# Altium schematic sheet TMC2209.SchDoc
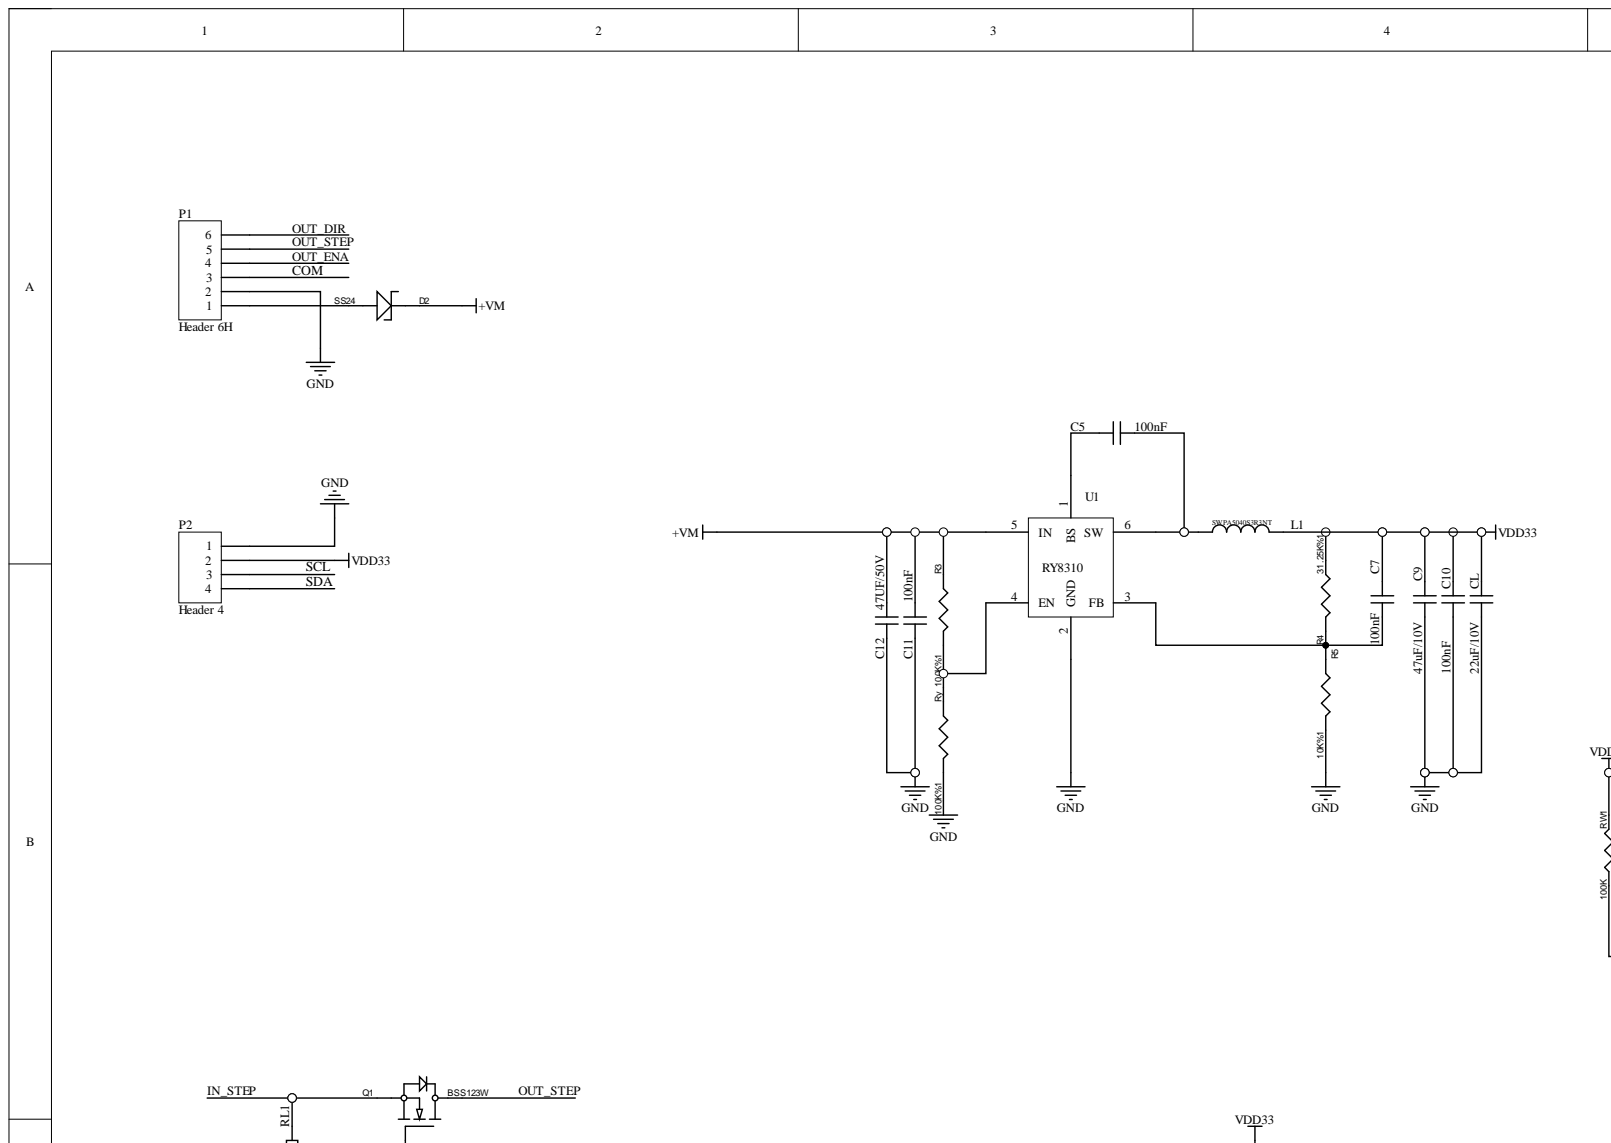
[diagram p0: TMC2209.SchDoc, top left]
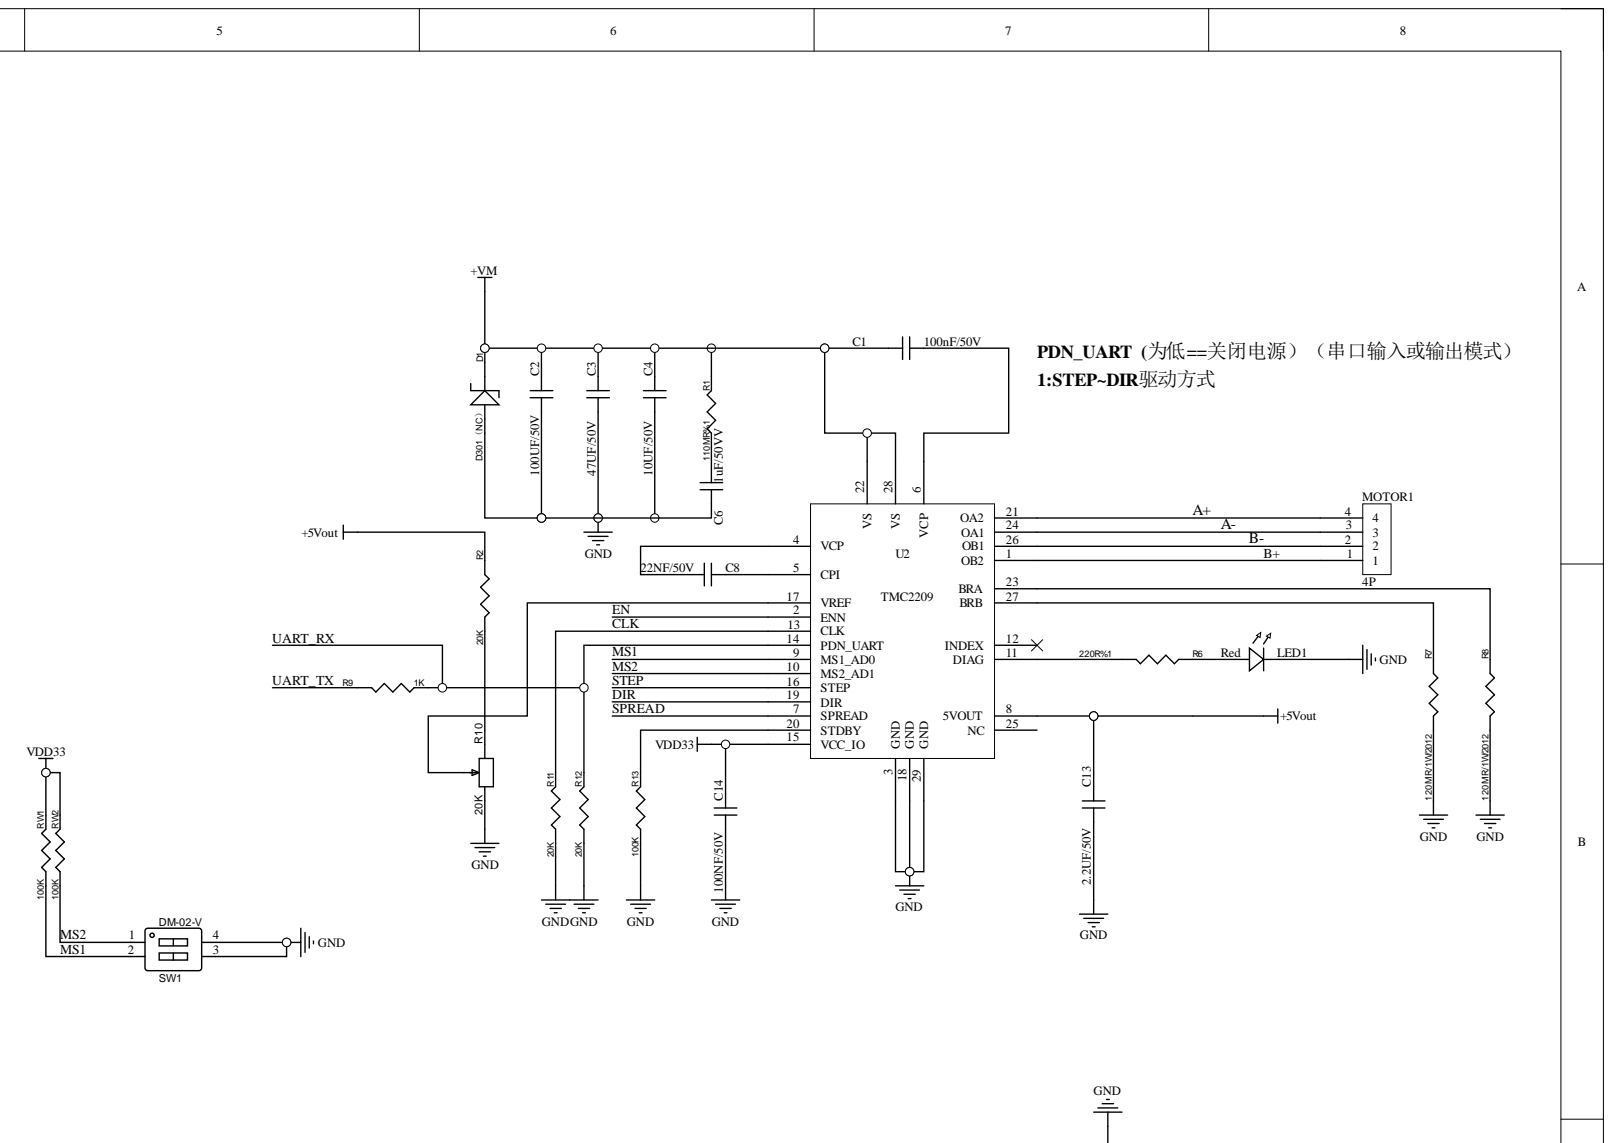
[diagram p1: TMC2209.SchDoc, top right]
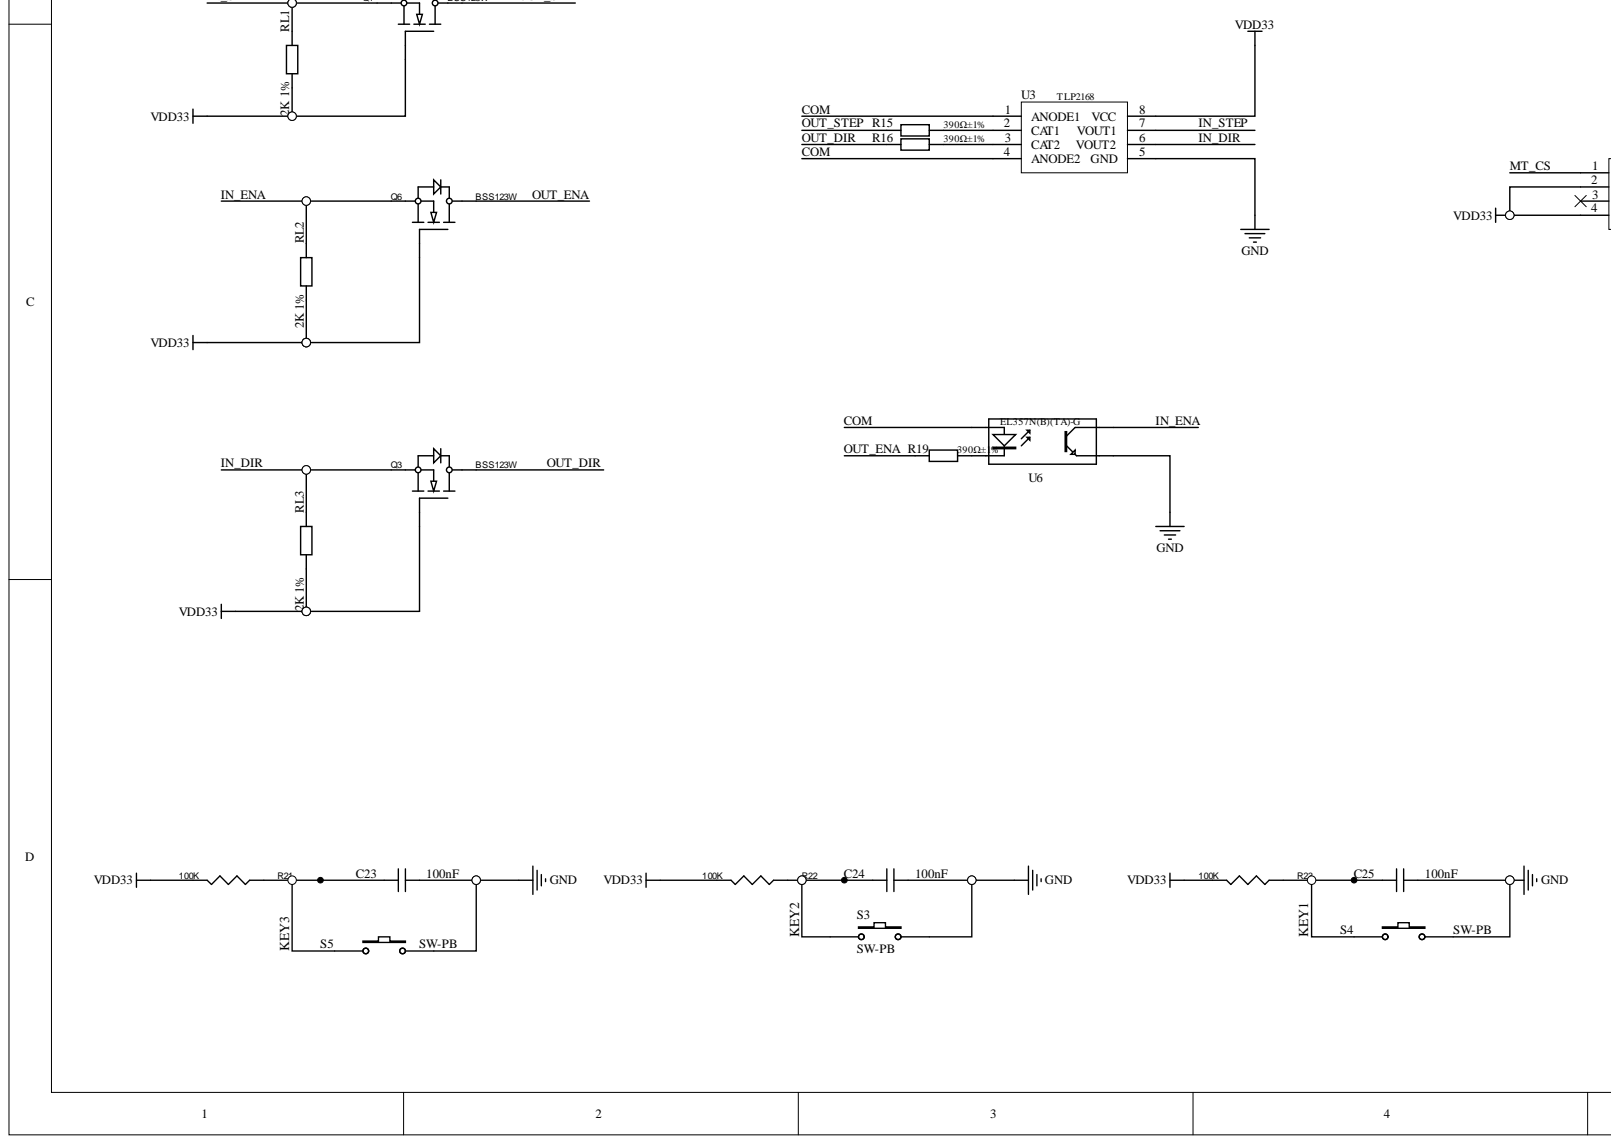
[diagram p2: TMC2209.SchDoc, bottom left]
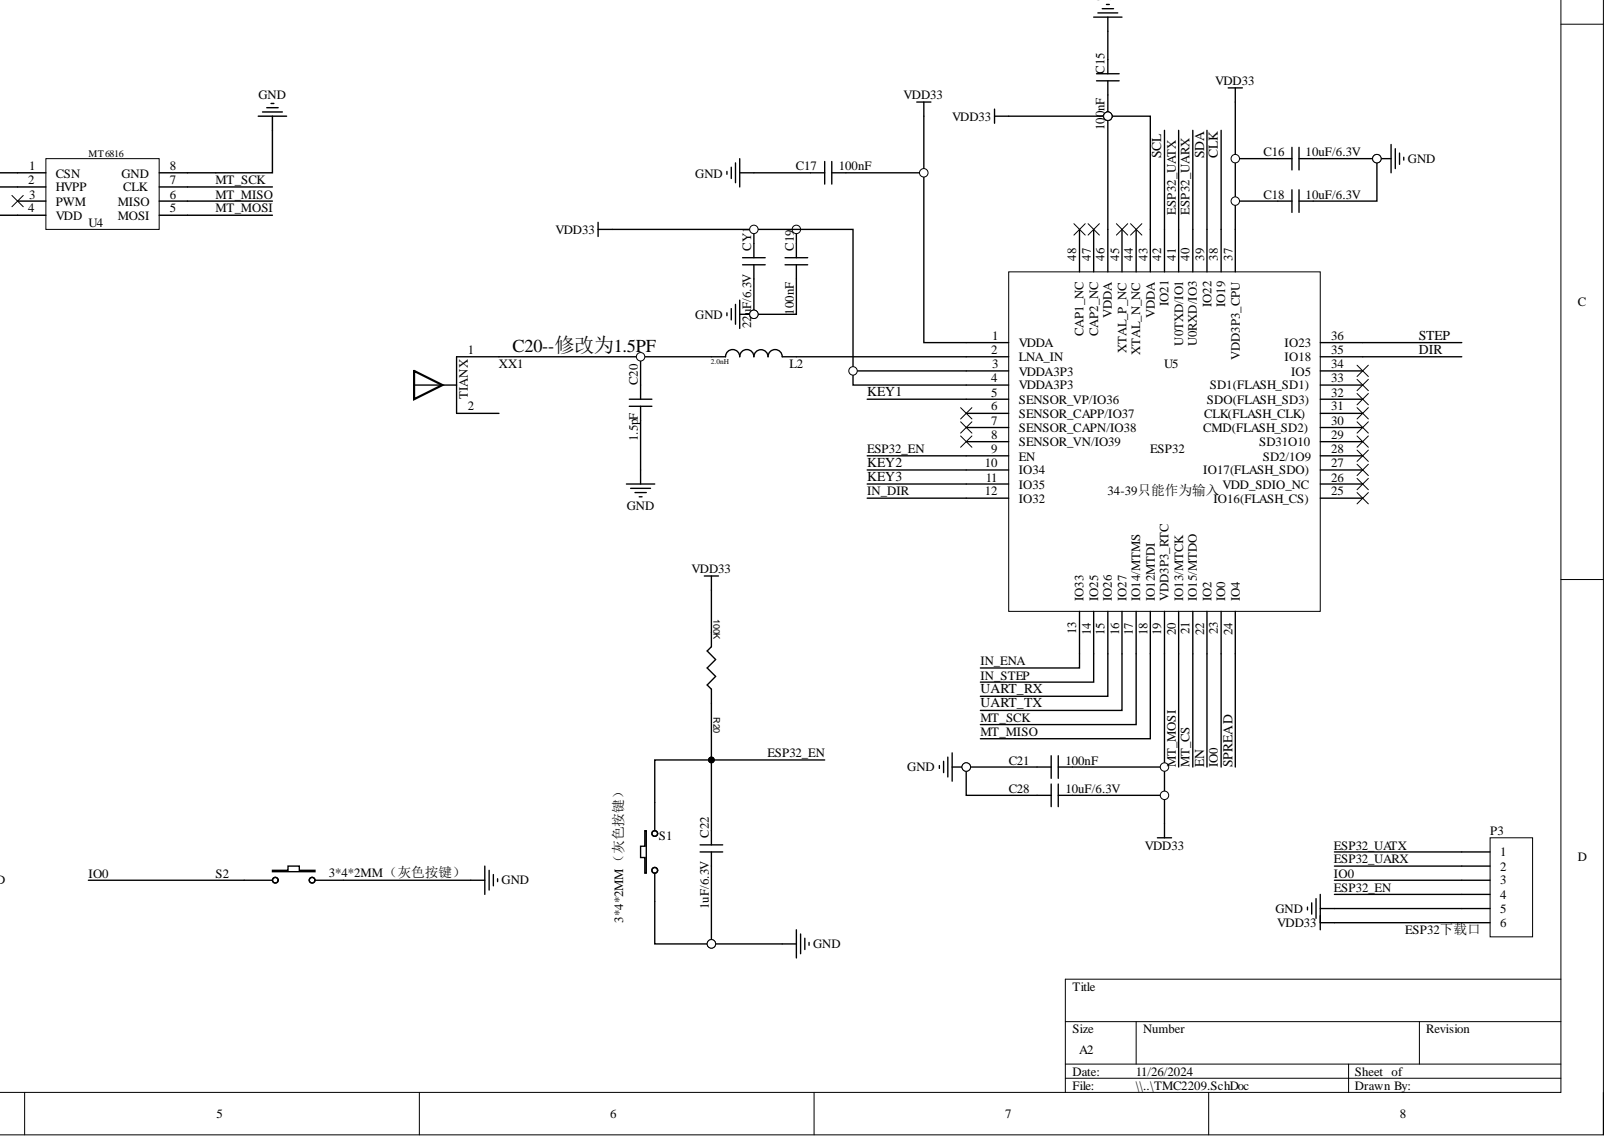
[diagram p3: TMC2209.SchDoc, bottom right]

## Parts
C1  100nF/50V  [LC-0603_C]
C2  100UF/50V  [CAP6377]
C3  47UF/50V  [LC-1206_C]
C4  10UF/50V  [LC-0805_C]
C5  100nF  [LC-0603_C]
C6  1uF/50VV  [LC-0603_C]
C7  100nF  [LC-0603_C]
C8  22NF/50V  [LC-0603_C]
C9  47uF/10V  [LC-1206_C]
C10  100nF  [LC-0603_C]
C11  100nF  [LC-0603_C]
C12  47UF/50V  [LC-1206_C]
C13  2.2UF/50V  [LC-0603_C]
C14  100NF/50V  [LC-0603_C]
C15  100nF  [LC-0603_C]
C16  10uF/6.3V  [LC-0603_C]
C17  100nF  [LC-0603_C]
C18  10uF/6.3V  [LC-0603_C]
C19  100nF  [LC-0603_C]
C20  1.5pF  [LC-0402_C]
C21  100nF  [LC-0603_C]
C22  1uF/6.3V  [LC-0603_C]
C23  100nF  [LC-0603_C]
C24  100nF  [LC-0603_C]
C25  100nF  [LC-0603_C]
C28  10uF/6.3V  [LC-0603_C]
CL  22uF/10V  [LC-0805_C]
CY1  22uF/6.3V  [LC-0603_C]
D1  D301（NC）  [M7]
D2  SS24  [DO-214AC]
L1  SWPA5040S3R3NT  [IND-SMD_L3.5-W3.0]
L2  2.0nH  [LC-0402_L]
LED1  Red  [LC-0603_LED_S1]
MOTOR1  4P  [CONN-TH_4P-P2.50_XH-4A]
P1  Header 6H  [CONN-TH_XH-6AW]
P2  Header 4  [HDR-TH_4P-P2.54-V-F]
P3  ESP32下载口  [6P-2.0MM - duplicate1]
Q1  BSS123W  [SOT-23-3L]
Q3  BSS123W  [SOT-23-3L]
Q6  BSS123W  [SOT-23-3L]
R1  110MR%1  [LC-0603_R]
R2  20K  [LC-0603_R]
R3  100K%1  [LC-0603_R]
R4  31.25K%1  [LC-0603_R]
R5  10K%1  [LC-0603_R]
R6  220R%1  [LC-0603_R]
R7  120MR/1W/2012  [LC-0805_L]
R8  120MR/1W/2012  [LC-0805_R]
R9  1K  [LC-0603_R]
R10  20K  [TRIMPOT-SMD-3MM-CLOSED]
R11  20K  [LC-0603_R]
R12  20K  [LC-0603_R]
R13  100K  [LC-0603_R]
R15  390Ω±1%  [LC-0603_R]
R16  390Ω±1%  [LC-0603_R]
R19  390Ω±1%  [LC-0603_R]
R20  100K  [LC-0603_R]
R21  100K  [LC-0603_R]
R22  100K  [LC-0603_R]
R23  100K  [LC-0603_R]
RL1  2K 1%  [LC-0603_R]
RL2  2K 1%  [LC-0603_R]
RL3  2K 1%  [LC-0603_R]
RW1  100K  [LC-0603_R]
RW2  100K  [LC-0603_R]
Ry  100K%1  [LC-0603_R]
S1  3*4*2MM（灰色按键）  [TS-018TS-018]
S2  3*4*2MM（灰色按键）  [TS-018TS-018]
S3  SW-PB  [KEY-4P]
S4  SW-PB  [KEY-4P]
S5  SW-PB  [KEY-4P]
SW1  DM-02-V  [SW-SMD_DM-02-V]
U1  RY8310  [SOT-23-6_L2.9-W1.6-P0.95-LS2.8-BR]
U2  TMC2209  [QFN-28_L5.0-W5.0-P0.50-BL-EP]
U3  TLP2168  [SO-8_3.9mm]
U4  MT6816  [SOP-8_L5.0-W4.0-P1.27-LS6.0-BL]
U5  ESP32  [QFN-48_7X7X05P]
U6  EL357N(B)(TA)-G  [SOP-4_L3.6-W4.4-P2.54-LS7.0-TL]
XX1  TIANX  [ANT-SMD_L5.2-W2.0]

## Nets
+5Vout: C13.2 R2.1 U2.8(5VOUT)
+VM: C1.2 C2.1 C3.1 C4.1 C11.2 C12.1 D1.K D2.2 R1.2 R3.1 U1.5(IN) U2.22(VS) U2.28(VS)
GND: C2.2 C3.2 C4.2 C6.2 C9.2 C10.1 C11.1 C12.2 C13.1 C14.1 C15.2 C16.2 C17.1 C18.2 C19.2 C20.1 C21.1 C22.2 C23.2 C24.2 C25.2 C28.1 CL.1 CY1.2 D1.A LED1.K P1.2 P2.1 P3.5 R5.2 R7.2 R8.2 R10.1 R11.1 R12.1 R13.1 Ry.2 S1.1 S2.2 S3.2 S4.2 S5.2 SW1.3 SW1.4 U1.2(GND) U2.3(GND) U2.18(GND) U2.29(GND) U3.5(GND) U4.8(GND) U6.3
VDD33: C7.2 C9.1 C10.2 C14.2 C15.1 C16.1 C17.2 C18.1 C19.1 C21.2 C28.2 CL.2 CY1.1 L1.2 P2.2 P3.6 Q1.1 Q3.1 Q6.1 R4.1 R20.2 R21.2 R22.2 R23.2 RL1.2 RL2.2 RL3.2 RW1.2 RW2.2 U2.15(VCC_IO) U3.8(VCC) U4.2(HVPP) U4.4(VDD) U5.1(VDDA) U5.3(VDDA3P3) U5.4(VDDA3P3) U5.19(VDD3P3_RTC) U5.37(VDD3P3_CPU) U5.43(VDDA) U5.46(VDDA)
A+: MOTOR1.4 U2.21(OA2)
A-: MOTOR1.3 U2.24(OA1)
B+: MOTOR1.1 U2.1(OB2)
B-: MOTOR1.2 U2.26(OB1)
CLK: R11.2 U2.13(CLK) U5.38(IO19)
COM: P1.3 U3.1(ANODE1) U3.4(ANODE2) U6.1
DIR: U2.19(DIR) U5.35(IO18)
EN: U2.2(ENN) U5.22(IO2)
ESP32_EN: C22.1 P3.4 R20.1 S1.2 U5.9(EN)
ESP32_UARX: P3.2 U5.40(U0RXD/IO3)
ESP32_UATX: P3.1 U5.41(U0TXD/IO1)
IN_DIR: Q3.2 RL3.1 U3.6(VOUT2) U5.12(IO32)
IN_ENA: Q6.2 RL2.1 U5.13(IO33) U6.4
IN_STEP: Q1.2 RL1.1 U3.7(VOUT1) U5.14(IO25)
IO0: P3.3 S2.1 U5.23(IO0)
KEY1: C25.1 R23.1 S4.1 U5.5(SENSOR_VP/IO36)
KEY2: C24.1 R22.1 S3.1 U5.10(IO34)
KEY3: C23.1 R21.1 S5.1 U5.11(IO35)
MS1: RW1.1 SW1.2 U2.9(MS1_AD0)
MS2: RW2.1 SW1.1 U2.10(MS2_AD1)
MT_CS: U4.1(CSN) U5.21(IO15/MTDO)
MT_MISO: U4.6(MISO) U5.18(IO12MTDI)
MT_MOSI: U4.5(MOSI) U5.20(IO13/MTCK)
MT_SCK: U4.7(CLK) U5.17(IO14/MTMS)
OUT_DIR: P1.6 Q3.3 R16.1
OUT_ENA: P1.4 Q6.3 R19.1
OUT_STEP: P1.5 Q1.3 R15.1
SCL: P2.3 U5.42(IO21)
SDA: P2.4 U5.39(IO22)
SPREAD: U2.7(SPREAD) U5.24(IO4)
STEP: U2.16(STEP) U5.36(IO23)
UART_RX: R9.1 R12.2 U2.14(PDN_UART) U5.15(IO26)
UART_TX: R9.2 U5.16(IO27)
NetC1_1: C1.1 U2.6(VCP)
NetC5_1: C5.1 U1.1(BS)
NetC5_2: C5.2 L1.1 U1.6(SW)
NetC6_1: C6.1 R1.1
NetC7_1: C7.1 R4.2 R5.1 U1.3(FB)
NetC8_1: C8.1 U2.4(VCP)
NetC8_2: C8.2 U2.5(CPI)
NetC20_2: C20.2 L2.1 XX1.1
NetD2_1: D2.1 P1.1
NetL2_2: L2.2 U5.2(LNA_IN)
NetLED1_A: LED1.A R6.1
NetR2_2: R2.2 R10.3
NetR3_2: R3.2 Ry.1 U1.4(EN)
NetR6_2: R6.2 U2.11(DIAG)
NetR7_1: R7.1 U2.27(BRB)
NetR8_1: R8.1 U2.23(BRA)
NetR10_2: R10.2 U2.17(VREF)
NetR13_2: R13.2 U2.20(STDBY)
NetR15_2: R15.2 U3.2(CAT1)
NetR16_2: R16.2 U3.3(CAT2)
NetR19_2: R19.2 U6.2

Not connected: U2 12(INDEX) 25(NC); U4 3(PWM); U5 6(SENSOR_CAPP/IO37) 7(SENSOR_CAPN/IO38) 8(SENSOR_VN/IO39) 25(IO16(FLASH_CS)) 26(VDD_SDIO_NC) 27(IO17(FLASH_SDO)) 28(SD2/1O9) 29(SD31O10) 30(CMD(FLASH_SD2)) 31(CLK(FLASH_CLK)) 32(SDO(FLASH_SD3)) 33(SD1(FLASH_SD1)) 34(IO5) 44(XTAL_N_NC) 45(XTAL_P_NC) 47(CAP2_NC) 48(CAP1_NC); XX1 2

## Library data of the parts
C1-C25 C28 CL CY1 = C: Component Kind: Standard; Library Name: 光学蓝牙.SCHLIB
D1 D2 = DIODE ZENER_4_1: room: power1; Component Kind: Standard; Library Name: 光学蓝牙.SCHLIB
L1 = (no symbol name): Power Inductor, 4.7uH, 0.7A, 260mR DCR; Manufacturer: Taiyo Yuden; Manufacturer Part Number: CBC2518T4R7M; Part Creator: JG; XMOS Part Number: E-09-0011; Approved: NO; Approx Volume Cost ($): 0.07; DEVICE: L; FORWARD_PCB: 1; LEVEL: STD; Max DC Resistance: 0.26R; Rated Current (Saturation): 0.68A; Rated Current (Temp Rise): 0.92A; Tolerance (%): 20; VALUE: 2.0nH; Component Kind: Standard; Datasheet: http://www.yuden.co.jp/us/product/pdf/ecb2_e.pdf; Digikey Link: http://search.digikey.com/scripts/DkSearch/dksus.dll?lang=en&site=US&keywords=CBC2518T4R7M; Library Name: MySchlib.SchLib; Max Height (mm): 2
L2 = (no symbol name): Power Inductor, 4.7uH, 0.7A, 260mR DCR; Manufacturer: Taiyo Yuden; Manufacturer Part Number: CBC2518T4R7M; Part Creator: JG; XMOS Part Number: E-09-0011; Approved: NO; Approx Volume Cost ($): 0.07; DEVICE: L; FORWARD_PCB: 1; LEVEL: STD; Max DC Resistance: 0.26R; Rated Current (Saturation): 0.68A; Rated Current (Temp Rise): 0.92A; Tolerance (%): 20; Component Kind: Standard; Datasheet: http://www.yuden.co.jp/us/product/pdf/ecb2_e.pdf; Digikey Link: http://search.digikey.com/scripts/DkSearch/dksus.dll?lang=en&site=US&keywords=CBC2518T4R7M; Library Name: MySchlib.SchLib; Max Height (mm): 2; VALUE: 2.0nH
LED1 = LED: Component Kind: Standard; Library Name: MyLibrary.IntLib
P1 = Header 6H: Header, 6-Pin, Right Angle; Component Kind: Standard; LatestRevisionDate: 17-Jul-2002; LatestRevisionNote: Re-released for DXP Platform.; Library Name: Miscellaneous Connectors.IntLib; Publisher: Altium Limited
P2 = Header 4: Header, 4-Pin; Component Kind: Standard; LatestRevisionDate: 17-Jul-2002; LatestRevisionNote: Re-released for DXP Platform.; Library Name: Miscellaneous Connectors.IntLib; Publisher: Altium Limited
P3 = Header 6: Header, 6-Pin; Component Kind: Standard; LatestRevisionDate: 17-Jul-2002; LatestRevisionNote: Re-released for DXP Platform.; Library Name: Miscellaneous Connectors.IntLib; Publisher: Altium Limited
Q1 Q3 Q6 = BSS123L_0: Component Kind: Standard
R1-R9 R11-R13 RW1 RW2 Ry = Res0603: Component Kind: Standard
R10 = 20K: BOM_Manufacturer: HDK; BOM_Manufacturer Part: VG039NCHXTB203; nameAlias: Resistance (Ohms); BOM_JLCPCB Part Class: Extended Part; BOM_Supplier: LCSC; BOM_Supplier Part: C128550; Component Kind: Standard; Resistance(Ohms): 20K; spicePre: R; spiceSymbolName: VG039NCHXTB203
R15 R16 R19 = 0603 390Ω (3900) 1%: 贴片电阻; Component Kind: Standard; ComponentLink1Description: 供应商链接; ComponentLink1URL: http://www.szlcsc.com/product/details_23878.html; Library Name: Miscellaneous Devices LC.IntLib; Package: 0603; Supplier: LC; SuppliersPartNumber: C23151
R20-R23 = R: Component Kind: Standard; Library Name: 光学蓝牙.SCHLIB
RL1-RL3 = Res2: Resistor; Value: 22r; Component Kind: Standard; LatestRevisionDate: 17-Jul-2002; LatestRevisionNote: Re-released for DXP Platform.; Library Name: MySchlib.SchLib; PackageDescription: Axial Device, Thru-Hole; 2 Leads; 0.4 in Pin Spacing; PackageReference: AXIAL-0.4; Published: 8-Jun-2000; Publisher: Altium Limited
S1-S5 = SW-PB: Switch; Component Kind: Standard; LatestRevisionDate: 17-Jul-2002; LatestRevisionNote: Re-released for DXP Platform.; Library Name: Miscellaneous Devices.IntLib; PackageReference: SPST-2; Published: 24-Mar-1999; Publisher: Altium Limited
SW1 = DM-02-V: BOM_Manufacturer: Diptronics; Contributor: LCSC; BOM_JLCPCB Part Class: Extended Part; BOM_Manufacturer Part: DM-02-V; BOM_Supplier: LCSC; BOM_Supplier Part: C501304; Component Kind: Standard; spicePre: S; spiceSymbolName: DM-02-V
U3 = TLP2168: HIGH SPEED 10MBit/s LOGIC GATE  PHOTOCOUPLER; Component Kind: Standard; Library Name: MySchib.SchLib
U4 = MT6816: GMR-Based Angle Sensor; Component Kind: Standard; Library Name: MySchib.SchLib
U5 = ESP32-7X7: Component Kind: Standard; Library Name: K210--Crazy.SCHLIB
U6 = EL357N(B)(TA)-G_[C6649]: 贴片光耦; Component Kind: Standard; ComponentLink1Description: 供应商链接; ComponentLink1URL: http://www.szlcsc.com/product/details_7114.html; Library Name: Miscellaneous Devices LC.IntLib; Package: SOP-4_P2.54; Supplier: LC; SuppliersPartNumber: C6649
MOTOR1 = 4P: Component Kind: Standard; Library Name: 光学蓝牙.SCHLIB
U1 = RY8310: Component Kind: Standard; Library Name: 885阿里云PCB_Project1.SCHLIB
U2 = TMC2209: Component Kind: Standard; Library Name: 885阿里云PCB_Project1.SCHLIB
XX1 = TIANX: Component Kind: Standard; Library Name: 885阿里云PCB_Project1.SCHLIB
